# Altium schematic sheet FAKE FCU.SchDoc
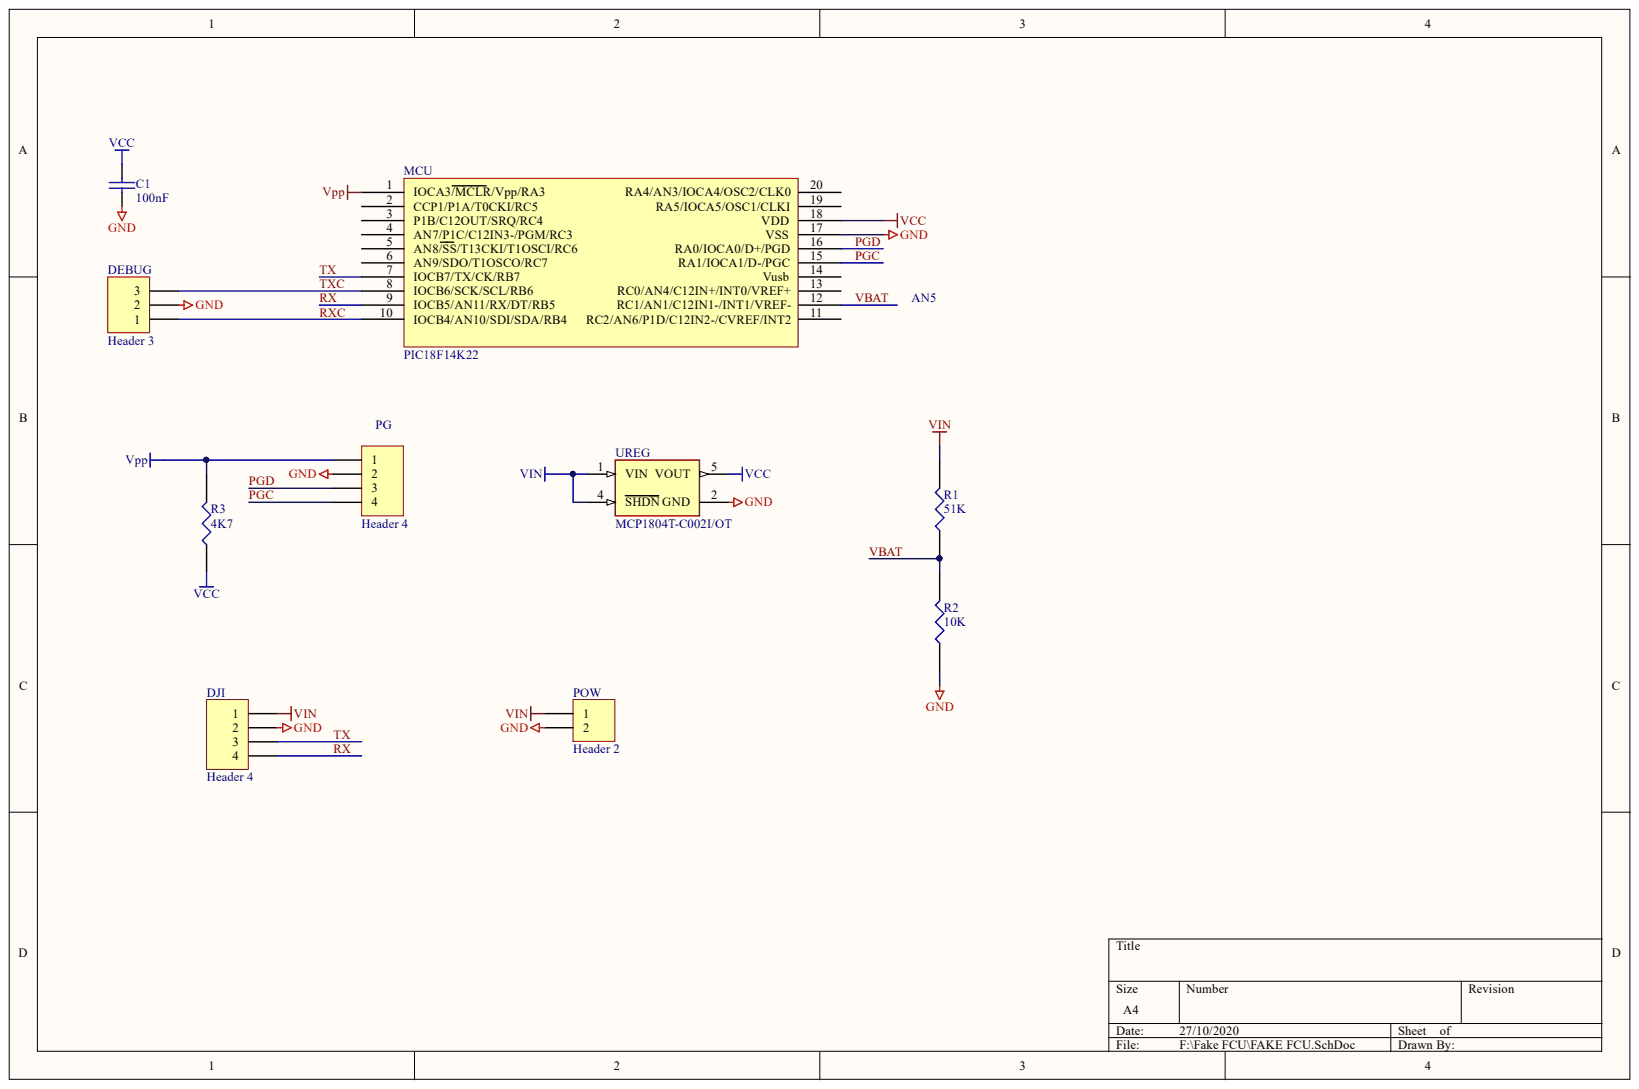
[diagram p0: FAKE FCU.SchDoc]

## Parts
C1  100nF  [0805]
DEBUG  Header 3  [debug]
DJI  Header 4  [HDR1X4]
MCU  PIC18F14K22  [QFN-ML20_L]
PG  Header 4  [prog4]
POW  Header 2  [powpad]
R1  51K  [0805]
R2  10K  [0805]
R3  4K7  [0805]
UREG  MCP1804T-C002I/OT  [SOT95P270X145-6N]

## Nets
GND: C1.2 DEBUG.2 DJI.2 MCU.17(VSS) PG.2 POW.2 R2.1 UREG.2(GND)
VCC: C1.1 MCU.18(VDD) R3.1 UREG.5(VOUT)
VIN: DJI.1 POW.1 R1.2 UREG.1(VIN) UREG.4(SHDN)
VPP: MCU.1(IOCA3/MCLR/Vpp/RA3) PG.1 R3.2
PGC: MCU.15(RA1/IOCA1/D-/PGC) PG.4
PGD: MCU.16(RA0/IOCA0/D+/PGD) PG.3
RX: DJI.4 MCU.9(IOCB5/AN11/RX/DT/RB5)
RXC: DEBUG.1 MCU.10(IOCB4/AN10/SDI/SDA/RB4)
TX: DJI.3 MCU.7(IOCB7/TX/CK/RB7)
TXC: DEBUG.3 MCU.8(IOCB6/SCK/SCL/RB6)
VBAT: MCU.12(RC1/AN1/C12IN1-/INT1/VREF-) R1.1 R2.2

Not connected: MCU 2(CCP1/P1A/T0CKI/RC5) 3(P1B/C12OUT/SRQ/RC4) 4(AN7/P1C/C12IN3-/PGM/RC3) 5(AN8/SS/T13CKI/T1OSCI/RC6) 6(AN9/SDO/T1OSCO/RC7) 11(RC2/AN6/P1D/C12IN2-/CVREF/INT2) 13(RC0/AN4/C12IN+/INT0/VREF+) 14(Vusb) 19(RA5/IOCA5/OSC1/CLKI) 20(RA4/AN3/IOCA4/OSC2/CLK0)

## Library data of the parts
C1 = CAP: Capacitor; Component Kind: Standard
DEBUG = Header 3: Header, 3-Pin; Component Kind: Standard; LatestRevisionDate: 17-Jul-2002; LatestRevisionNote: Re-released for DXP Platform.; Library Name: Miscellaneous Connectors.IntLib; Publisher: Altium Limited
DJI PG = Header 4: Header, 4-Pin; Component Kind: Standard; LatestRevisionDate: 17-Jul-2002; LatestRevisionNote: Re-released for DXP Platform.; Library Name: Miscellaneous Connectors.IntLib; Publisher: Altium Limited
MCU = PIC18F14K50: Component Kind: Standard; Library Name: rc_lights.SCHLIB
POW = Header 2: Header, 2-Pin; Component Kind: Standard; LatestRevisionDate: 17-Jul-2002; LatestRevisionNote: Re-released for DXP Platform.; Library Name: Miscellaneous Connectors.IntLib; Publisher: Altium Limited
R1-R3 = RES1: Component Kind: Standard
UREG = MCP1804T-C002I/OT: Linear Voltage Regulator IC 1 Output 150mA SOT-23-5; MF: Microchip; Availability: Warning; Check_prices: https://www.snapeda.com/parts/MCP1804T-C002I/OT/Microchip/view-part/235602/?ref=eda; Component Kind: Standard; Library Name: MCP1804T-C002I_OT.IntLib; MP: MCP1804T-C002I/OT; Package: SOT-23-5 Microchip; Price: None; Purchase-URL: https://pricing.snapeda.com/search/part/MCP1804T-C002I/OT/?ref=eda; SnapEDA_Link: https://www.snapeda.com/parts/MCP1804T-C002I/OT/Microchip/view-part/235602/?ref=snap
